# Altium schematic sheet MINI-STM32.SchDoc
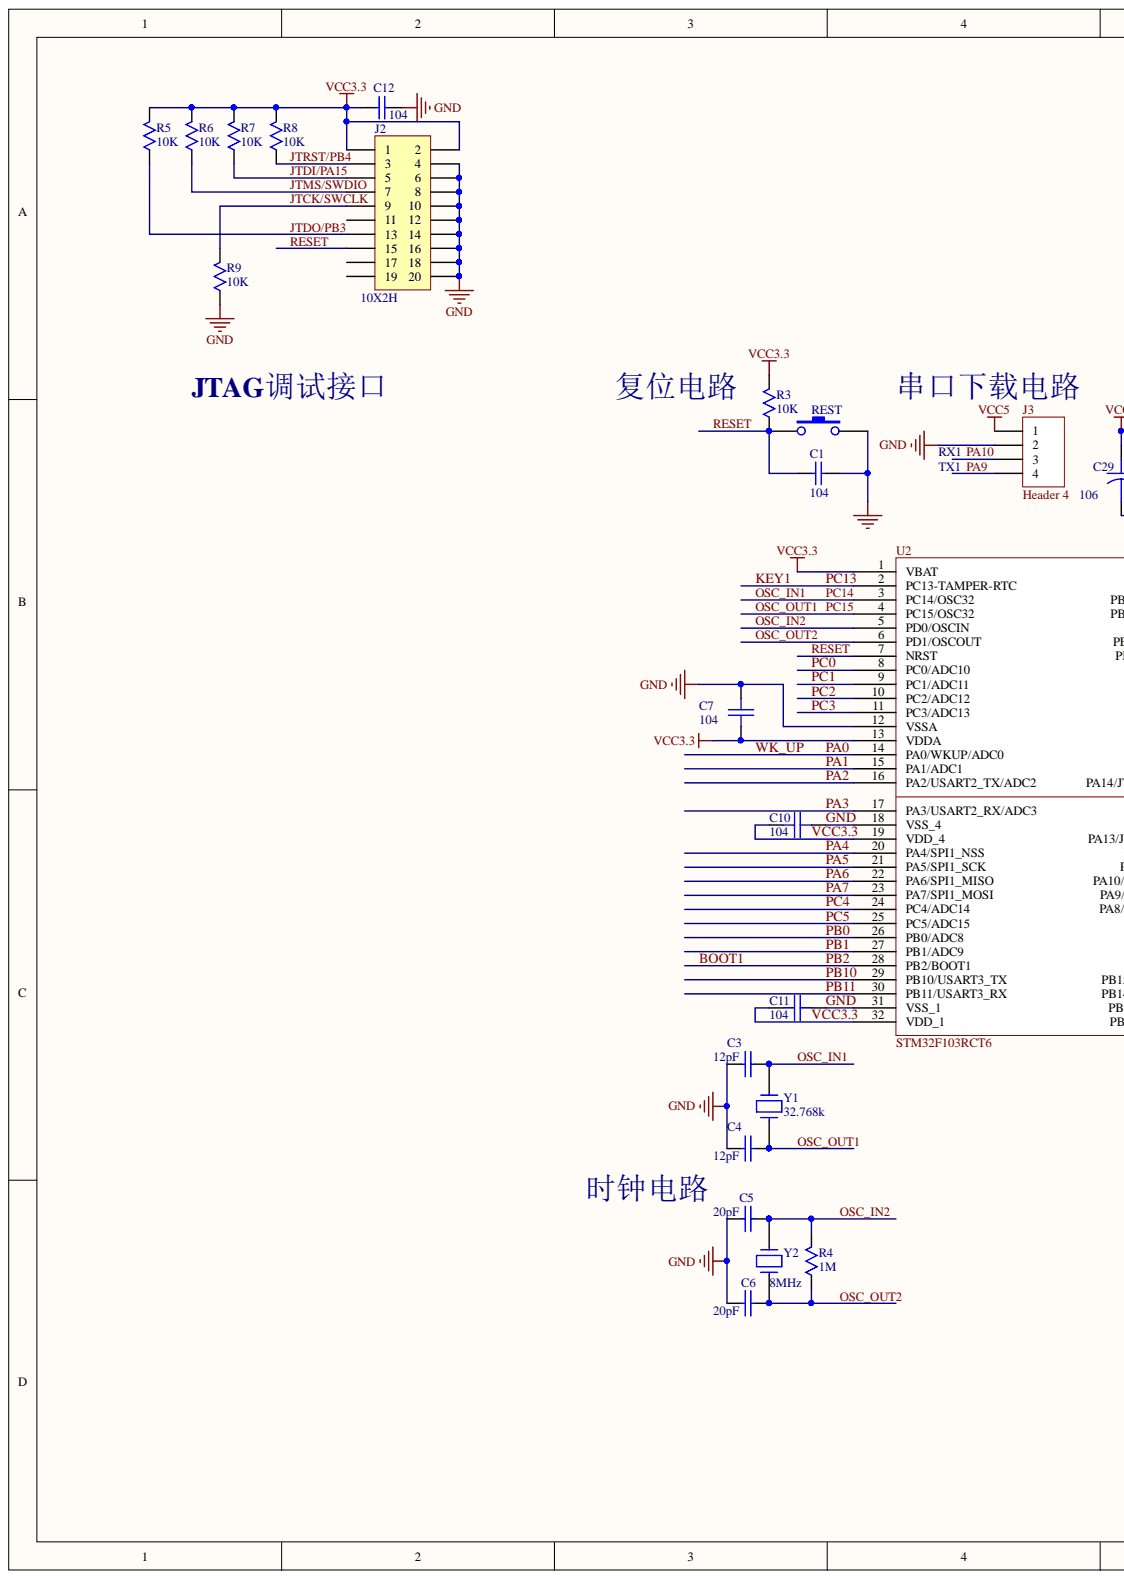
[diagram p0: MINI-STM32.SchDoc, left]
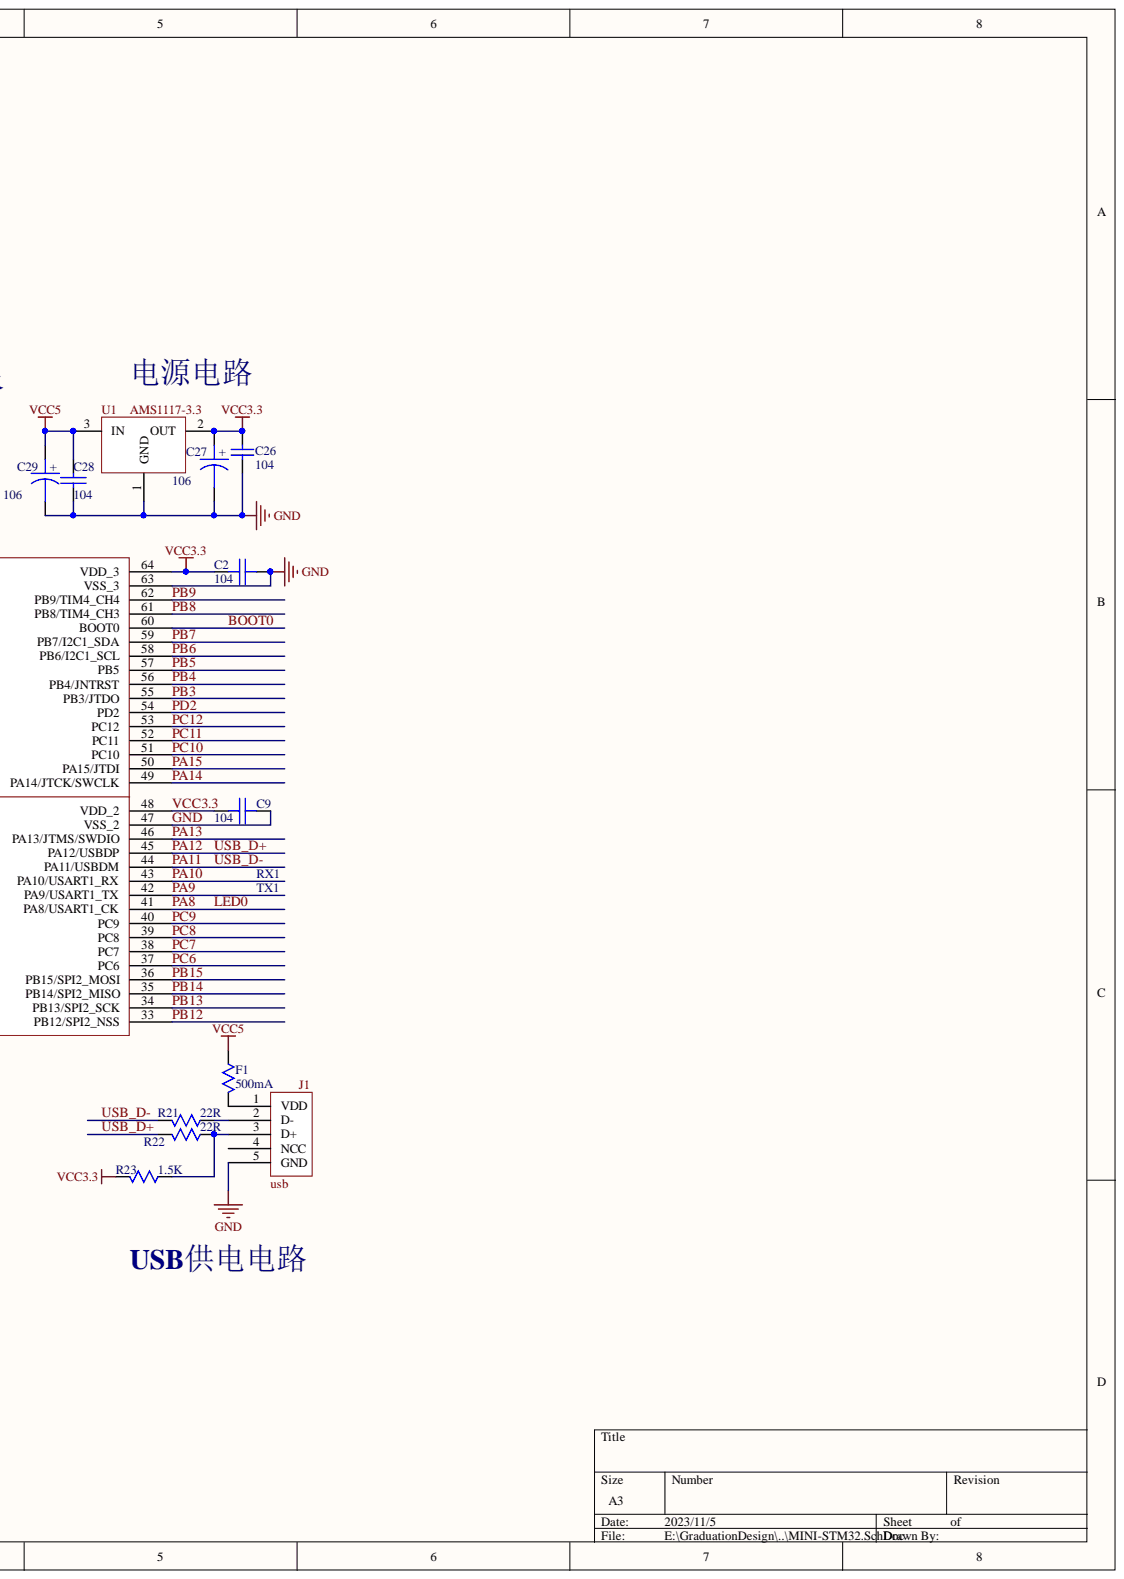
[diagram p1: MINI-STM32.SchDoc, right]

## Parts
C1  104  [0603C]
C2  104  [0603J]
C3  12pF  [0603J]
C4  12pF  [0603J]
C5  20pF  [0603J]
C6  20pF  [0603J]
C7  104  [0603J]
C9  104  [0603J]
C10  104  [0603J]
C11  104  [0603J]
C12  104  [0603C]
C26  104  [0603J]
C27  106  [RC0805]
C28  104  [0603J]
C29  106  [RC0805]
F1  500mA  [RC0805]
J1  usb  [mini_USB]
J2  10X2H  [IDC20]
J3  Header 4  [HDR1X4]
R3  10K  [0603J]
R4  1M  [0603J]
R5  10K  [0603J]
R6  10K  [0603J]
R7  10K  [0603J]
R8  10K  [0603J]
R9  10K  [0603J]
R21  22R  [0603J]
R22  22R  [0603J]
R23  1.5K  [0603J]
REST  (no value)  [SW-SPST]
U1  AMS1117-3.3  [SOT223]
U2  STM32F103RCT6  [LQFP64]
Y1  32.768k  [32.768k]
Y2  8MHz  [smt-xtal]

## Nets
VCC5: C28.1 C29.1 F1.1 J3.1 U1.3
BOOT0: U2.60(BOOT0)
BOOT1: U2.28(PB2/BOOT1)
GND: C1.1 C2.2 C3.1 C4.1 C5.1 C6.1 C7.1 C9.2 C10.2 C11.2 C12.2 C26.2 C27.2 C28.2 C29.2 J1.5(GND) J2.4 J2.6 J2.8 J2.10 J2.12 J2.14 J2.16 J2.18 J2.20 J3.2 R9.1 REST.2 U1.1 U2.12(VSSA) U2.18(VSS_4) U2.31(VSS_1) U2.47(VSS_2) U2.63(VSS_3)
JTCK/SWCLK: J2.9 R9.2
JTDI/PA15: J2.5 R7.1
JTDO/PB3: J2.13 R5.1
JTMS/SWDIO: J2.7 R6.1
JTRST/PB4: J2.3 R8.1
KEY1: U2.2(PC13-TAMPER-RTC)
LED0: U2.41(PA8/USART1_CK)
OSC_IN1: C3.2 U2.3(PC14/OSC32) Y1.2
OSC_IN2: C5.2 R4.2 U2.5(PD0/OSCIN) Y2.1
OSC_OUT1: C4.2 U2.4(PC15/OSC32) Y1.1
OSC_OUT2: C6.2 R4.1 U2.6(PD1/OSCOUT) Y2.2
PA0: U2.14(PA0/WKUP/ADC0)
PA1: U2.15(PA1/ADC1)
PA2: U2.16(PA2/USART2_TX/ADC2)
PA3: U2.17(PA3/USART2_RX/ADC3)
PA4: U2.20(PA4/SPI1_NSS)
PA5: U2.21(PA5/SPI1_SCK)
PA6: U2.22(PA6/SPI1_MISO)
PA7: U2.23(PA7/SPI1_MOSI)
PA9: J3.4 U2.42(PA9/USART1_TX)
PA10: J3.3 U2.43(PA10/USART1_RX)
PA11: R21.2 U2.44(PA11/USBDM)
PA12: R22.2 U2.45(PA12/USBDP)
PA13: U2.46(PA13/JTMS/SWDIO)
PA14: U2.49(PA14/JTCK/SWCLK)
PA15: U2.50(PA15/JTDI)
PB0: U2.26(PB0/ADC8)
PB1: U2.27(PB1/ADC9)
PB3: U2.55(PB3/JTDO)
PB4: U2.56(PB4/JNTRST)
PB5: U2.57(PB5)
PB6: U2.58(PB6/I2C1_SCL)
PB7: U2.59(PB7/I2C1_SDA)
PB8: U2.61(PB8/TIM4_CH3)
PB9: U2.62(PB9/TIM4_CH4)
PB10: U2.29(PB10/USART3_TX)
PB11: U2.30(PB11/USART3_RX)
PB12: U2.33(PB12/SPI2_NSS)
PB13: U2.34(PB13/SPI2_SCK)
PB14: U2.35(PB14/SPI2_MISO)
PB15: U2.36(PB15/SPI2_MOSI)
PC0: U2.8(PC0/ADC10)
PC1: U2.9(PC1/ADC11)
PC2: U2.10(PC2/ADC12)
PC3: U2.11(PC3/ADC13)
PC4: U2.24(PC4/ADC14)
PC5: U2.25(PC5/ADC15)
PC6: U2.37(PC6)
PC7: U2.38(PC7)
PC8: U2.39(PC8)
PC9: U2.40(PC9)
PC10: U2.51(PC10)
PC11: U2.52(PC11)
PC12: U2.53(PC12)
PD2: U2.54(PD2)
RESET: C1.2 J2.15 R3.1 REST.1 U2.7(NRST)
VCC3.3: C2.1 C7.2 C9.1 C10.1 C11.1 C12.1 C26.1 C27.1 J2.1 J2.2 R3.2 R5.2 R6.2 R7.2 R8.2 R23.2 U1.2 U2.1(VBAT) U2.13(VDDA) U2.19(VDD_4) U2.32(VDD_1) U2.48(VDD_2) U2.64(VDD_3)
NetF1_2: F1.2 J1.1(VDD)
NetJ1_2: J1.2(D-) R21.1
NetJ1_3: J1.3(D+) R22.1 R23.1

Not connected: J1 4(NCC); J2 11 17 19

## Library data of the parts
C1 C12 = CAP: GRM39 X7R102K50PT; Value: 100pF; Component Kind: Standard; Library Name: Miscellaneous Devices.IntLib; Published: 8-Jun-2000; REF: GRM39 X7R102K50PT; Revision: July-2002: Re-released for DXP Platform.; Signal Integrity: Cap; Simulation: CAP; Publisher: Altium Limited
C2 C7 C9-C11 = CAP: Component Kind: Standard; Library Name: YT1_SCH_99SE.SCHLIB
C3 C4 = CAP: CAP CHIP 20P 50V(+-5% C0G 0603); Value: 20pF; Component Kind: Standard; Library Name: Miscellaneous Devices.IntLib
C5 C6 = CAP: CAP CHIP 20P 50V(+-5% C0G 0603); Component Kind: Standard; Library Name: Miscellaneous Devices.IntLib; Value: 20pF
C26 = Cap: Capacitor; Component Kind: Standard; LatestRevisionDate: 17-Jul-2002; LatestRevisionNote: Re-released for DXP Platform.; PackageReference: RAD-0.3; Published: 8-Jun-2000; Publisher: Altium Limited
C27 C29 = CAPACITOR POL: Capacitor; Component Kind: Standard
C28 = CAP: Capacitor; Component Kind: Standard
F1 R3-R9 R21-R23 = RES: Component Kind: Standard; Library Name: YT1_SCH_99SE.SCHLIB
J2 = Header 10X2H: Header, 10-Pin, Dual row, Right Angle; Component Kind: Standard; LatestRevisionDate: 17-Jul-2002; LatestRevisionNote: Re-released for DXP Platform.; Library Name: Miscellaneous Connectors.IntLib; Signal Integrity: Connector; Publisher: Altium Limited
J3 = Header 4: Header, 4-Pin; Component Kind: Standard; LatestRevisionDate: 17-Jul-2002; LatestRevisionNote: Re-released for DXP Platform.; Library Name: Miscellaneous Connectors.IntLib; Signal Integrity: Connector; Publisher: Altium Limited
REST = SW-PB: Component Kind: Standard
U1 = LT1085CM-3.3: Component Kind: Standard; Library Name: Newcapec9263_Board_NEW.SchLib
U2 = STM32F103RBT6: Component Kind: Standard; Library Name: YT1_SCH_99SE.SCHLIB
Y1 Y2 = CRYSTAL: Crystal 8MHz PLUG; Component Kind: Standard; Value: 8MHz
J1 = usb: Component Kind: Standard; Library Name: YT1_SCH_99SE.SCHLIB
